# Altium schematic sheet LS1.SchDoc(LS1)
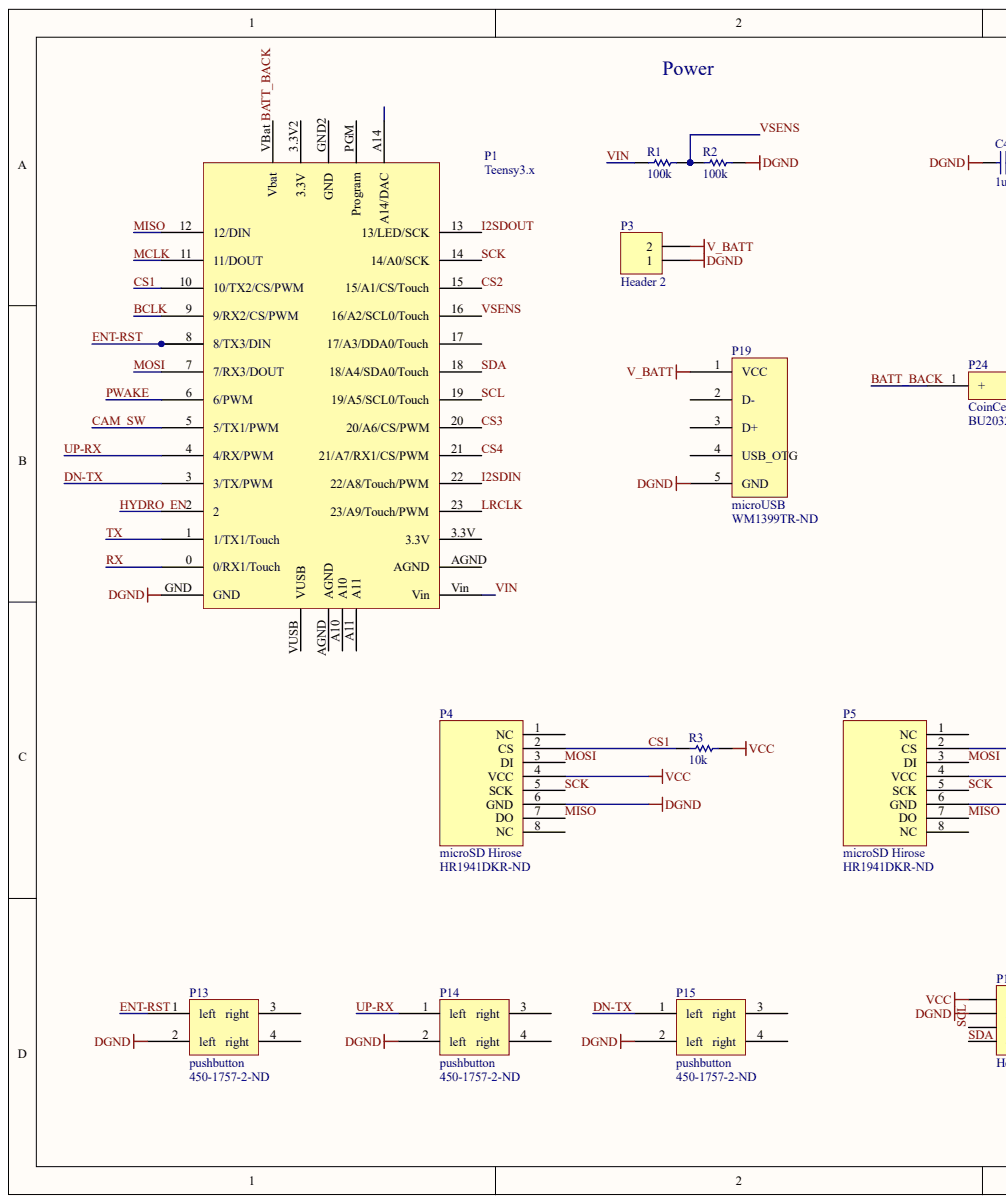
[diagram p0: LS1.SchDoc, left]
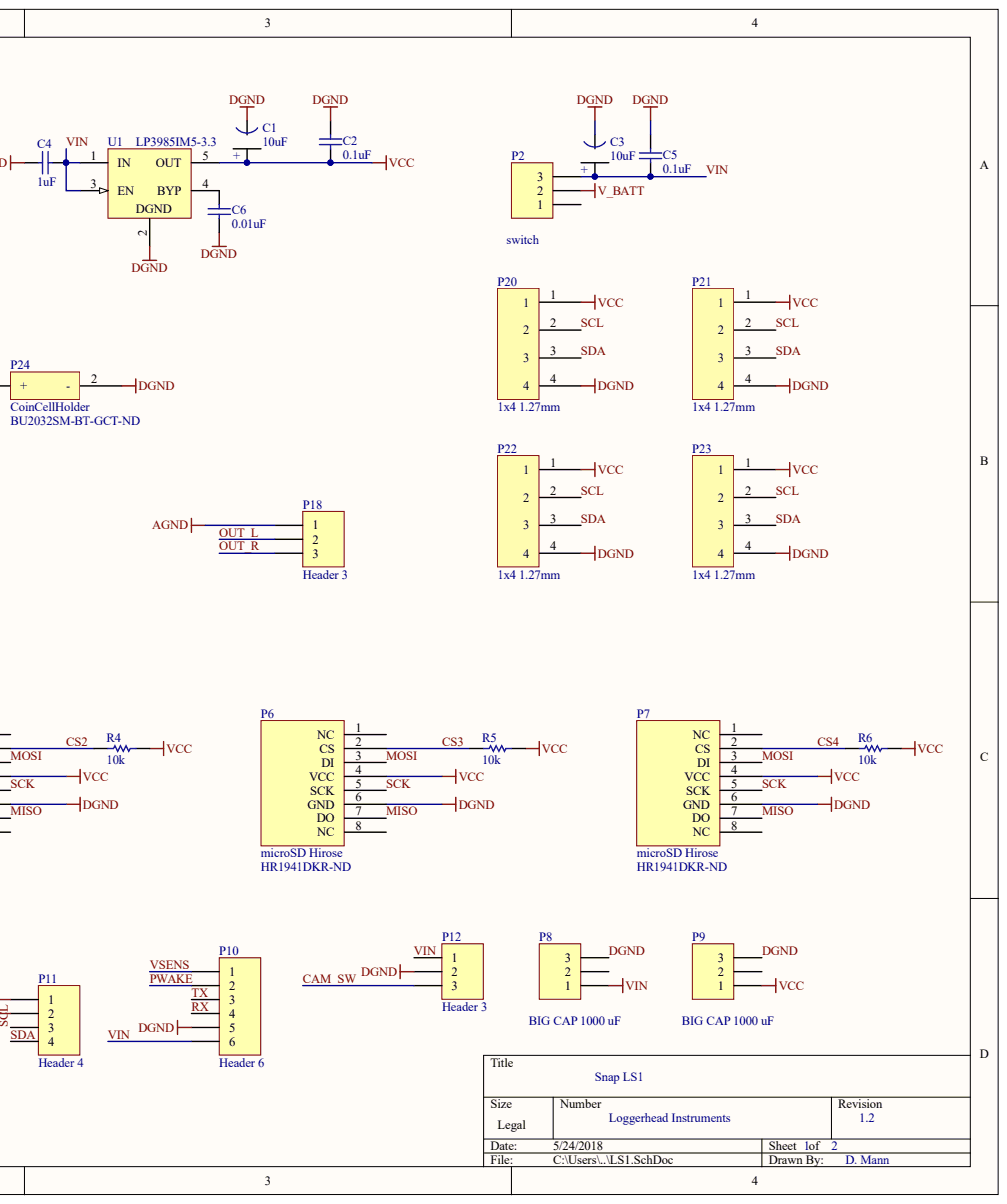
[diagram p1: LS1.SchDoc, right]

## Parts
C1  10uF
C2  0.1uF
C3  10uF
C4  1uF
C5  0.1uF
C6  0.01uF
P1  Teensy3.x
P2  switch
P3  Header 2
P4  microSD Hirose  Supplier Part Number 1: HR1941DKR-ND
P5  microSD Hirose  Supplier Part Number 1: HR1941DKR-ND
P6  microSD Hirose  Supplier Part Number 1: HR1941DKR-ND
P7  microSD Hirose  Supplier Part Number 1: HR1941DKR-ND
P8  BIG CAP 1000 uF
P9  BIG CAP 1000 uF
P10  Header 6
P11  Header 4
P12  Header 3
P13  pushbutton  Supplier Part Number 1: 450-1757-2-ND
P14  pushbutton  Supplier Part Number 1: 450-1757-2-ND
P15  pushbutton  Supplier Part Number 1: 450-1757-2-ND
P18  Header 3
P19  microUSB  Supplier Part Number 1: WM1399TR-ND
P20  1x4 1.27mm
P21  1x4 1.27mm
P22  1x4 1.27mm
P23  1x4 1.27mm
P24  CoinCellHolder  Supplier Part Number 1: BU2032SM-BT-GCT-ND
R1  100k
R2  100k
R3  10k
R4  10k
R5  10k
R6  10k
U1  LP3985IM5-3.3

## Nets
AGND: P18.1
V_BATT: P2.2 P3.2 P19.1(VCC)
VCC: C1.2 C2.1 P4.4(VCC) P5.4(VCC) P6.4(VCC) P7.4(VCC) P9.1 P11.1 P20.1 P21.1 P22.1 P23.1 R3.1 R4.1 R5.1 R6.1 U1.5(OUT)
BATT_BACK: P1.VBat(Vbat) P24.1
BCLK: P1.9(9/RX2/CS/PWM)
CAM_SW: P1.5(5/TX1/PWM) P12.3
CS1: P1.10(10/TX2/CS/PWM) P4.2(CS) R3.2
CS2: P1.15(15/A1/CS/Touch) P5.2(CS) R4.2
CS3: P1.20(20/A6/CS/PWM) P6.2(CS) R5.2
CS4: P1.21(21/A7/RX1/CS/PWM) P7.2(CS) R6.2
DGND: C1.1 C2.2 C3.1 C4.2 C5.2 C6.2 P1.GND P3.1 P4.6(GND) P5.6(GND) P6.6(GND) P7.6(GND) P8.3 P9.3 P10.5 P11.2 P12.2 P13.2(left) P14.2(left) P15.2(left) P19.5(GND) P20.4 P21.4 P22.4 P23.4 P24.2 R2.1 U1.2
DN-TX: P1.3(3/TX/PWM) P15.1(left)
ENT-RST: P1.8(8/TX3/DIN) P13.1(left)
HYDRO_EN: P1.2
I2SDIN: P1.22(22/A8/Touch/PWM)
I2SDOUT: P1.13(13/LED/SCK)
LRCLK: P1.23(23/A9/Touch/PWM)
MCLK: P1.11(11/DOUT)
MISO: P1.12(12/DIN) P4.7(DO) P5.7(DO) P6.7(DO) P7.7(DO)
MOSI: P1.7(7/RX3/DOUT) P4.3(DI) P5.3(DI) P6.3(DI) P7.3(DI)
OUT_L: P18.2
OUT_R: P18.3
PWAKE: P1.6(6/PWM) P10.2
RX: P1.0(0/RX1/Touch) P10.4
SCK: P1.14(14/A0/SCK) P4.5(SCK) P5.5(SCK) P6.5(SCK) P7.5(SCK)
SCL: P1.19(19/A5/SCL0/Touch) P11.3 P20.2 P21.2 P22.2 P23.2
SDA: P1.18(18/A4/SDA0/Touch) P11.4 P20.3 P21.3 P22.3 P23.3
TX: P1.1(1/TX1/Touch) P10.3
UP-RX: P1.4(4/RX/PWM) P14.1(left)
VIN: C3.2 C4.1 C5.1 P1.Vin P2.3 P8.1 P10.6 P12.1 R1.2 U1.1(IN) U1.3(EN)
VSENS: P1.16(16/A2/SCL0/Touch) P10.1 R1.1 R2.2
NetC6_1: C6.1 U1.4(BYP)

Not connected: P1 3.3V 3.3V2(3.3V) 17(17/A3/DDA0/Touch) A10 A11 A14(A14/DAC) AGND GND2(GND) PGM(Program) VUSB; P2 1; P4 1(NC) 8(NC); P5 1(NC) 8(NC); P6 1(NC) 8(NC); P7 1(NC) 8(NC); P8 2; P9 2; P13 3(right) 4(right); P14 3(right) 4(right); P15 3(right) 4(right); P19 2(D-) 3(D+) 4

## Library data of the parts
C2 C5 = (no symbol name): Note: Footprint corresponds to dimensions for bulk cassette packaging. This case size has tightened tolerances.; Published: 17-Jul-2002; LatestRevisionDate: 19-Mar-2004; LatestRevisionNote: Silkscreen size reduced.; DatasheetDocument: Mar-2001; PackageDocument: Mar-2001; PackageReference: 0402; Code_JEITA: 0402; Code_IPC: 1005 [0402]; ComponentLink1Description: Manufacturer Link; ComponentLink1URL: http://www.kemet.com/; ComponentLink2Description: Datasheet; ComponentLink2URL: http://www.kemet.com/kemet/web/homepage/kechome.nsf/vapubfilesname/F3102TA.pdf/$file/F3102TA.pdf; Value: 0.1uF; Publisher: Altium Limited
C4 C6 = (no symbol name): Note: Footprint corresponds to dimensions for bulk cassette packaging. This case size has tightened tolerances.; Value: 0.1uF; Published: 17-Jul-2002; LatestRevisionDate: 19-Mar-2004; LatestRevisionNote: Silkscreen size reduced.; DatasheetDocument: Mar-2001; PackageDocument: Mar-2001; PackageReference: 0402; Code_JEITA: 0402; Code_IPC: 1005 [0402]; ComponentLink1Description: Manufacturer Link; ComponentLink1URL: http://www.kemet.com/; ComponentLink2Description: Datasheet; ComponentLink2URL: http://www.kemet.com/kemet/web/homepage/kechome.nsf/vapubfilesname/F3102TA.pdf/$file/F3102TA.pdf; Publisher: Altium Limited
U1 = (no symbol name): Manufacturer: National Semiconductor; CompType: LDO IC; PackageTopMarking: LDQB*XTT; PowerWise: N; Published: 4-Dec-2008; DatasheetVersion: Aug-2005; PackageVersion: revD, Aug-2008; PackageReference: MF05A; PackageDescription: Molded SOT-23, .115 x .063 x .040 in Body, 5 Lead, .0375 in Pitch; PartNumber: LP3985IM5-3.3; RoHS: O; PinCount: 5; DigiKeyPartNumber: LP3985IM5-3.3CT-ND; ComponentLink1Description: LP3985 Datasheet; ComponentLink1URL: http://www.national.com/ds/LP/LP3985.pdf; ComponentLink2Description: LP3985 Web Page; ComponentLink2URL: http://www.national.com/pf/LP/LP3985.html; ComponentLink3Description: MF05A Package Page; ComponentLink3URL: http://www.national.com/packaging/folders/mf05a.html; Publisher: Altium Limited
C1 C3 P1-P15 P18-P24 R1-R6 = (no symbol name)
  C1 C3: Value: 10uF
  P2 P3 P8-P12 P18: LatestRevisionDate: 17-Jul-2002; LatestRevisionNote: Re-released for DXP Platform.
  P4-P7 P13-P15 P19 P24: Supplier 1: Digi-Key
  R1-R6: Published: 17-Dec-2003; LatestRevisionDate: 19-Mar-2004; LatestRevisionNote: Silkscreen size reduced.; PackageDocument: Mar-2003; PackageReference: 3-0603; Code_IPC: 1608 [0603]; ComponentLink1Description: Manufacturer Link; ComponentLink1URL: http://www.panasonic.com/industrial/components/resistive_products.htm; ComponentLink2Description: Datasheet; ComponentLink2URL: http://www.panasonic.com/industrial/components/pdf/AOA0000CE2.pdf
  P2 P3 P8-P12 P18 R1-R6: Publisher: Altium Limited
